# Altium schematic sheet RSB Bluebit Schematic.SchDoc("Bluebit Schematic")
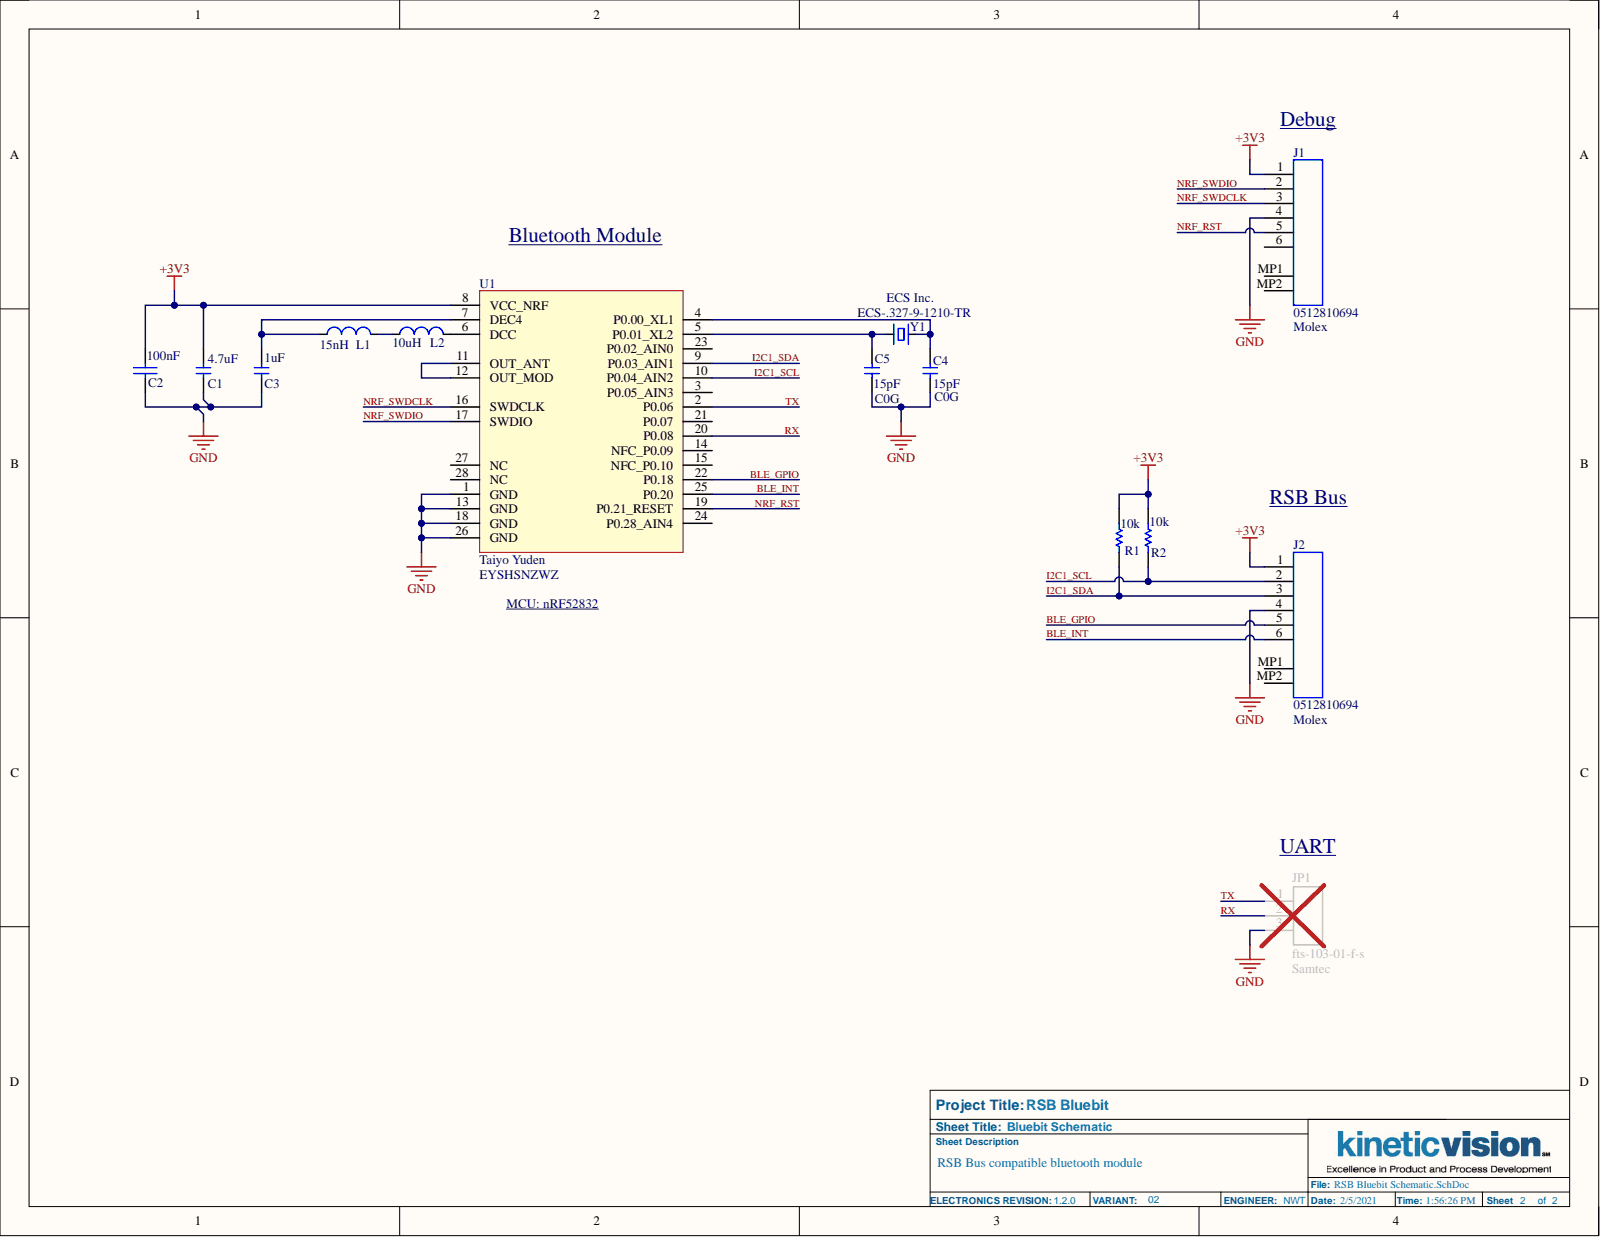
[diagram p0: RSB Bluebit Schematic.SchDoc]

## Parts
C1  Capacitance: 4.7uF  [CAP0201]  (hidden comment: GRM035R60J475ME15D)
C2  Capacitance: 100nF  [CAP0201]  (hidden comment: CL03A104KP3NNNC)
C3  Capacitance: 1uF  [CAP0201]  (hidden comment: CL03A105KP3NSNC)
C4  Capacitance: 15pF  Dielectric: C0G  [CAP0201]  (hidden comment: GRM0335C1H150FA01D)
C5  Capacitance: 15pF  Dielectric: C0G  [CAP0201]  (hidden comment: GRM0335C1H150FA01D)
J1  Manufacturer: Molex  Manufacturer Part Number: 0512810694  [MOLX-51281-0694]  (hidden comment: Molex 51281-0694)
J2  Manufacturer: Molex  Manufacturer Part Number: 0512810694  [MOLX-51281-0694]  (hidden comment: Molex 51281-0694)
JP1  Manufacturer: Samtec  [fts-103-01-f-s]  (hidden comment: FTS-103-01-F-S)
L1  Inductance: 15nH  [IND0201]  (hidden comment: LQP03TN15NH02D)
L2  Inductance: 10uH  [IND0603]  (hidden comment: LB1608T100M)
R1  Resistance: 10k  [RES0201]  (hidden comment: ERJ-1GNF1002C)
R2  Resistance: 10k  [RES0201]  (hidden comment: ERJ-1GNF1002C)
U1  EYSHSNZWZ  Manufacturer: Taiyo Yuden  [EYSHSNZWZ-Footprint-1]
Y1  ECS-.327-9-1210-TR  Manufacturer: ECS Inc.  [ECX-1210]

## Nets
+3V3: C1.2 C2.2 J1.1 J2.1 R1.2 R2.2 U1.8(VCC_NRF)
GND: C1.1 C2.1 C3.1 C4.2 C5.2 J1.4 J2.4 JP1.3 U1.1(GND) U1.13(GND) U1.18(GND) U1.26(GND)
BLE_GPIO: J2.5 U1.22(P0.18)
BLE_INT: J2.6 U1.25(P0.20)
I2C1_SCL: J2.2 R2.1 U1.10(P0.04_AIN2)
I2C1_SDA: J2.3 R1.1 U1.9(P0.03_AIN1)
NRF_RST: J1.5 U1.19(P0.21_RESET)
NRF_SWDCLK: J1.3 U1.16(SWDCLK)
NRF_SWDIO: J1.2 U1.17(SWDIO)
RX: JP1.2 U1.20(P0.08)
TX: JP1.1 U1.2(P0.06)
NetC3_2: C3.2 L1.2 U1.7(DEC4)
NetC4_1: C4.1 U1.4(P0.00_XL1) Y1.2
NetC5_1: C5.1 U1.5(P0.01_XL2) Y1.1
NetL1_1: L1.1 L2.2
NetL2_1: L2.1 U1.6(DCC)
NetU1_11: U1.11(OUT_ANT) U1.12(OUT_MOD)

Not connected: J1 6 MP1 MP2; J2 MP1 MP2; U1 3(P0.05_AIN3) 14(NFC_P0.09) 15(NFC_P0.10) 21(P0.07) 23(P0.02_AIN0) 24(P0.28_AIN4) 27(NC) 28(NC)

## Library data of the parts
C1 = CMP-00201.1.0.00: Ceramic Capacitor, Multilayer, Ceramic, 6.3V, 20% +Tol, 20% -Tol, X5R, 15% TC, 4.7uF, Surface Mount, 0201; Manufacturer: Murata Electronics; Case Code (Imperial): 0201; Case Code (Metric): 0603; Case/Package: 0201; Component Kind: Standard; Dielectric: X5R; Length: 0.024inch; Manufacturer Part Number: GRM035R60J475ME15D; Max Operating Temperature: 85°C; Min Operating Temperature: -55°C; Mount: Surface Mount; Packaging: Tape and Reel; Thickness: 0.022inch; Tolerance: 20%; Voltage Rating: 6.3V; Voltage Rating (DC): 6.3V; Width: 0.012inch; Library Name: Kinetic Vision A365
C2 = CMP-00208.1.0.00: Ceramic Capacitor, Multilayer, Ceramic, 10V, 10% +Tol, 10% -Tol, X5R, 15% TC, 0.1uF, Surface Mount, 0201; Manufacturer: Samsung; Manufacturer Part Number: CL03A104KP3NNC; Case Code (Imperial): 0201; Case Code (Metric): 0603; Case/Package: 0201; Component Kind: Standard; Contact Plating: Tin; Depth: 0.3mm; Dielectric: X5R; Height: 0.3mm; Lead Free: Lead Free; Length: 0.6mm; Max Operating Temperature: 85°C; Min Operating Temperature: -55°C; Mount: Surface Mount; Package Quantity: 1; Packaging: Tape and Reel; Radiation Hardening: No; Thickness: 0.013inch; Tolerance: 10%; Voltage Rating: 10V; Voltage Rating (DC): 10V; Width: 0.012inch; Library Name: Kinetic Vision A365
C3 = CMP-00007-0.0.10: CAP CER 1UF 10V X5R 0201; Manufacturer: Samsung; Min Operating Temeprature: -55°C; Tolerance: 10%; Case Code (Imperial): 0201; Case Code (Metric): 0603; Case/Package: 0201; Component Kind: Standard; Length: 0.024inch; Manufacturer Part Number: CL03A105KP3NSNC; Max Operating Temperature: 85°C; Min Operating Temperature: -55°C; Mount: Surface Mount; Packaging: Cut Tape; Supplier: Digikey; Supplier Part Number: 1276-6795-1-ND; Thickness: 0.013inch; Voltage Rating: 10V; Width: 0.012inch; Library Name: Kinetic Vision A365
C4 C5 = CMP-00205.1.0.00: Ceramic Capacitor, Multilayer, Ceramic, 50V, 1% +Tol, 1% -Tol, C0G, 30ppm/Cel TC, 0.000015uF, Surface Mount, 0201; Manufacturer: Murata Electronics; Manufacturer Part Number: GRM0335C1H4R3BA01D; Component Kind: Standard; Max Operating Temperature: 125°C; Min Operating Temperature: -55°C; Supplier: Murata; Supplier Part Number: GRM0335C1H150FA01D; Tolerance: 1%; Voltage Rating: 50V; Library Name: Kinetic Vision A365
J1 J2 = CMP-00017.1.0.07: MOLEX   51281-0694   FFC / FPC Board Connector, Non-ZIF, Surface Mount, Receptacle, 6 Contacts, Top, Bottom, 0.5 mm; Contact Resistance: 20mR; Current Rating: 500mA; Number of Circuits: 6; Pitch: 0.5mm; Stackable: No; Voltage Rating: 50V; Component Kind: Standard; Contact Material: Bronze; Contact Plating: Gold; Depth: 3.75mm; ELV: Compliant; Gender: Receptacle; Halogen Free: Low Halogen; Housing Color: Natural; Housing Material: Thermoplastic; Length: 5.1mm; Material: Bronze; Max Operating Temperature: 85°C; Min Operating Temperature: -20°C; Number of Contacts: 6; Number of Positions: 6; Number of Rows: 1; Orientation: Right Angle; Package Quantity: 3000; Packaging: Tape and Reel; REACH SVHC: Unknown; Supplier: Digkey; Supplier Part Number: WM10922CT-ND; Termination: SMD/SMT; Library Name: Kinetic Vision A365
JP1 = CMP-00207.1.0.00: Board Connector, 3 Contact(s), 1 Row(s), Male, Straight, Solder Terminal; Number of Contacts: 3; Pitch: 1.27mm; Component Kind: Standard; Contact Plating: Gold; Gender: Male; Manufacturer Part Number: fts-103-01-f-s; Max Operating Temperature: 105°C; Min Operating Temperature: -40°C; Mount: Through Hole; Number of Rows: 1; Packaging: Bulk; Radiation Hardening: No; Termination: Solder; Library Name: Kinetic Vision A365
L1 = CMP-00206.1.0.01: General Purpose Inductor, 0.015uH, 3%, 1 Element, SMD, 0201; Manufacturer: Murata; Core Material: Non-Magnetic; Current Rating: 250mA; DC Resistance (DCR): 700mR; Q Factor: 12; Self Resonant Frequency: 2.6GHz; Shielding: Unshielded; Test Frequency: 500MHz; Case Code (Imperial): 0201; Case Code (Metric): 0603; Case/Package: 0201; Component Kind: Standard; Composition: Thin Film; DC Current: 250mA; Depth: 0.33mm; Height: 0.3mm; Height - Seated (Max): 0.013inch; Length: 0.024inch; Manufacturer Part Number: LQP03TN15NH02D; Max DC Current: 250mA; Max Operating Temperature: 125°C; Military Standard: Not; Min Operating Temperature: -55°C; Mount: Surface Mount; Package Quantity: 15000; Packaging: Tape and Reel; Radiation Hardening: No; Termination: SMD/SMT; Tolerance: 3%; Width: 0.3mm; Library Name: Kinetic Vision A365
L2 = CMP-00204-1.0.01: General Purpose Inductor, 10uH, 20%, 1 Element, Ferrite-Core, SMD, 0603; Manufacturer: Taiyo Yuden; Current Rating: 60mA; DC Resistance (DCR): 700mR; Self Resonant Frequency: 32MHz; Shielding: Unshielded; Test Frequency: 2.52MHz; Case Code (Imperial): 0603; Case Code (Metric): 1608; Case/Package: 0603; Component Kind: Standard; Composition: Wirewound; Core Material: Ferrite; DC Current: 60mA; Depth: 0.9mm; Halogen Free: Halogen Free; Height: 0.8mm; Height - Seated (Max): 0.035inch; Inductance Tolerance: 20%; Lead Free: Lead Free; Length: 0.063inch; Manufacturer Part Number: LB1608T100M; Max DC Current: 60mA; Max Operating Temperature: 105°C; Military Standard: Not; Min Operating Temperature: -40°C; Mount: Surface Mount; Package Quantity: 1; Packaging: Tape and Reel; Radiation Hardening: No; REACH SVHC: No SVHC; Termination: SMD/SMT; Tolerance: 20%; Width: 0.031inch; Library Name: Kinetic Vision A365
R1 R2 = CMP-00000.0.0.13: RES SMD 10K OHM 1% 1/20W 0201; Manufacturer: Panasonic Electronic Components; Max Power Dissipation: 50mW; Temperature Coefficient: 200ppm/°C; Voltage Rating: 25V; Case Code (Imperial): 0201; Case Code (Metric): 0603; Case/Package: 0201; Component Kind: Standard; Composition: Thick Film; Contact Plating: Tin,Matte; Depth: 0.3mm; Height: 0.01inch; Lead Free: Lead Free; Length: 0.6mm; Manufacturer Part Number: ERJ-1GNF1002C; Max Operating Temperature: 125°C; Military Standard: Not; Min Operating Temperature: -55°C; Mount: Surface Mount; Number of Pins: 2; Number of Terminations: 2; Package Quantity: 15000; Packaging: Tape and Reel; Power Rating: 50mW; Radiation Hardening: No; REACH SVHC: Unknown; Tolerance: 1%; Voltage Rating (DC): 25V; Width: 0.012inch; Library Name: Kinetic Vision A365
U1 = CMP-001-0005.1.0.02: Telecom Circuit; Current - Receiving: 11.7mA; Current - Transmitting: 16mA; Data Rate: 1Mbps; Frequency: 2.4GHz; Interface: UART; Memory Size: 64KB; Sensitivity: -94dBm; Case/Package: Module; Component Kind: Standard; Manufacturer Part Number: EYSHSNZWZ; Max Operating Temperature: 85°C; Min Operating Temperature: -40°C; Mount: Surface Mount; Packaging: Tape and Reel; Library Name: Kinetic Vision A365
Y1 = CMP-00203.1.0.01: 32.768kHz ±20ppm Crystal 9pF 90 kOhms 2-SMD, No Lead; ESR (Equivalent Series Resistance): 90kR; Case/Package: SMD/SMT; Component Kind: Standard; Frequency: 32.768kHz; Frequency Tolerance: 20ppm; Load Capacitance: 9pF; Manufacturer Part Number: ECS-.327-9-1210-TR; Max Operating Temperature: 85°C; Min Operating Temperature: -40°C; Mount: Surface Mount; Packaging: Tape and Reel; Library Name: Kinetic Vision A365
